# Altium schematic sheet SCH_Modules.SchDoc(SCH_Modules)
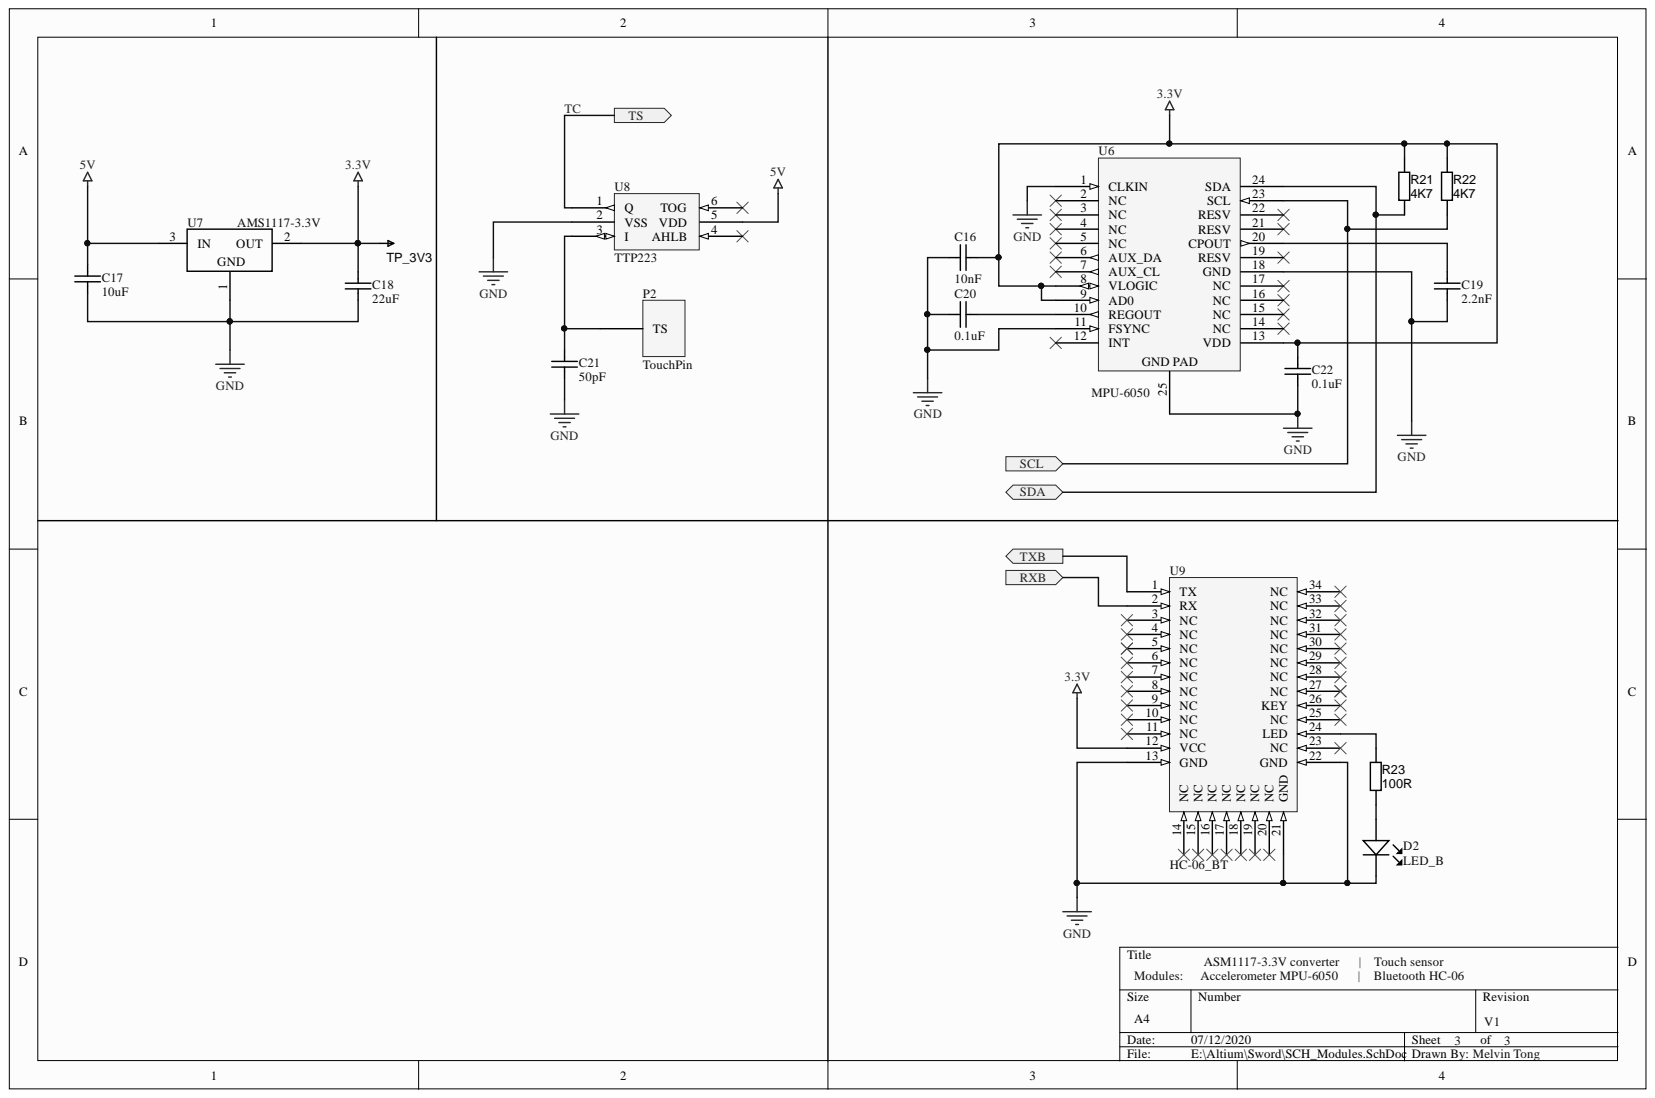
[diagram p0: SCH_Modules.SchDoc]

## Parts
C16  10nF  [CAP_0805]
C17  10uF  [CAP_1206]
C18  22uF  [CAP_1206]
C19  2.2nF  [CAP_0805]
C20  0.1uF  [CAP_0805]
C21  50pF  [CAP_0805]
C22  0.1uF  [CAP_0805]
D2  LED_B  [LED_0805]
P2  TouchPin  [TouchPad]
R21  4K7  [RESC3216X70N]
R22  4K7  [RESC3216X70N]
R23  100R  [RESC3216X70N]
TP_3V3  TESTPOINT  [TP-R20]  (value not drawn)  (no BOM)
U6  MPU-6050  [MPU-6050]
U7  AMS1117-3.3V  [SOT223]
U8  TTP223  [TTP223-BA6]
U9  HC-06_BT  [HC-06_BT]

## Ports
RXB, SCL, SDA, TS, TXB

## Nets
3.3V: C16.2 C18.1 C22.2 R21.1 R22.1 TP_3V3.1 U6.8(VLOGIC) U6.9(AD0) U6.13(VDD) U7.2 U9.12(VCC)
5V: C17.1 U7.3 U8.5(VDD)
GND: C16.1 C17.2 C18.2 C19.2 C20.1 C21.2 C22.1 D2.K U6.1(CLKIN) U6.11(FSYNC) U6.18(GND) U6.25 U7.1 U8.2(VSS) U9.13(GND) U9.21(GND) U9.22(GND)
RXB: U9.2(RX) ; port RXB
SCL: R22.2 U6.23(SCL) ; port SCL
SDA: R21.2 U6.24(SDA) ; port SDA
TC: U8.1(Q) ; port TS
TXB: U9.1(TX) ; port TXB
NetC19_1: C19.1 U6.20(CPOUT)
NetC20_2: C20.2 U6.10(REGOUT)
NetC21_1: C21.1 P2.1 U8.3(I)
NetD2_A: D2.A R23.2
NetR23_1: R23.1 U9.24(LED)

Not connected: U6 2(NC) 3(NC) 4(NC) 5(NC) 6(AUX_DA) 7(AUX_CL) 12(INT) 14(NC) 15(NC) 16(NC) 17(NC) 19(RESV) 21(RESV) 22(RESV); U8 4(AHLB) 6(TOG); U9 3(NC) 4(NC) 5(NC) 6(NC) 7(NC) 8(NC) 9(NC) 10(NC) 11(NC) 14(NC) 15(NC) 16(NC) 17(NC) 18(NC) 19(NC) 20(NC) 23(NC) 25(NC) 26(KEY) 27(NC) 28(NC) 29(NC) 30(NC) 31(NC) 32(NC) 33(NC) 34(NC)

## Library data of the parts
C16 = CAP_10nF: SMD 0805; Component Kind: Standard; Library Name: Schlib_Sword.SchLib
C17 = CAP_10uF: SMD 0805/1206; Component Kind: Standard; Library Name: Schlib_Sword.SchLib
C18 = CAP_22uF: SMD 1206; Component Kind: Standard; Library Name: Schlib_Sword.SchLib
C19 = CAP_2.2nF: SMD 0805; Component Kind: Standard; Library Name: Schlib_Sword.SchLib
C20 C22 = CAP_0.1uF: SMD 0805; Component Kind: Standard; Library Name: Schlib_Sword.SchLib
C21 = CAP_50pF: SMD 0805; Component Kind: Standard; Library Name: Schlib_Sword.SchLib
D2 = LED_B: SMD_0805 3V; Component Kind: Standard; Library Name: Schlib_Sword.SchLib
R21 R22 = RES_4K7: Resistor SMD, 1206; Value: N/A; Component Kind: Standard; Library Name: Schlib_Sword.SchLib
R23 = RES_100R: Resistor SMD, 1206; Value: N/A; Component Kind: Standard; Library Name: Schlib_Sword.SchLib
TP_3V3 = TESTPOINT: SMD test pin; Library Name: Schlib_Sword.SchLib
P2 = TouchPin: Component Kind: Standard; Library Name: Schlib_Sword.SchLib
U6 = MPU-6050: Component Kind: Standard; Library Name: Schlib_Sword.SchLib
U7 = AMS1117-3.3V: Component Kind: Standard; Library Name: Schlib_Sword.SchLib
U8 = TTP223: Component Kind: Standard; Library Name: Schlib_Sword.SchLib
U9 = HC-06_BT: Component Kind: Standard; Library Name: Schlib_Sword.SchLib
